# Altium schematic sheet sem4200.SchDoc
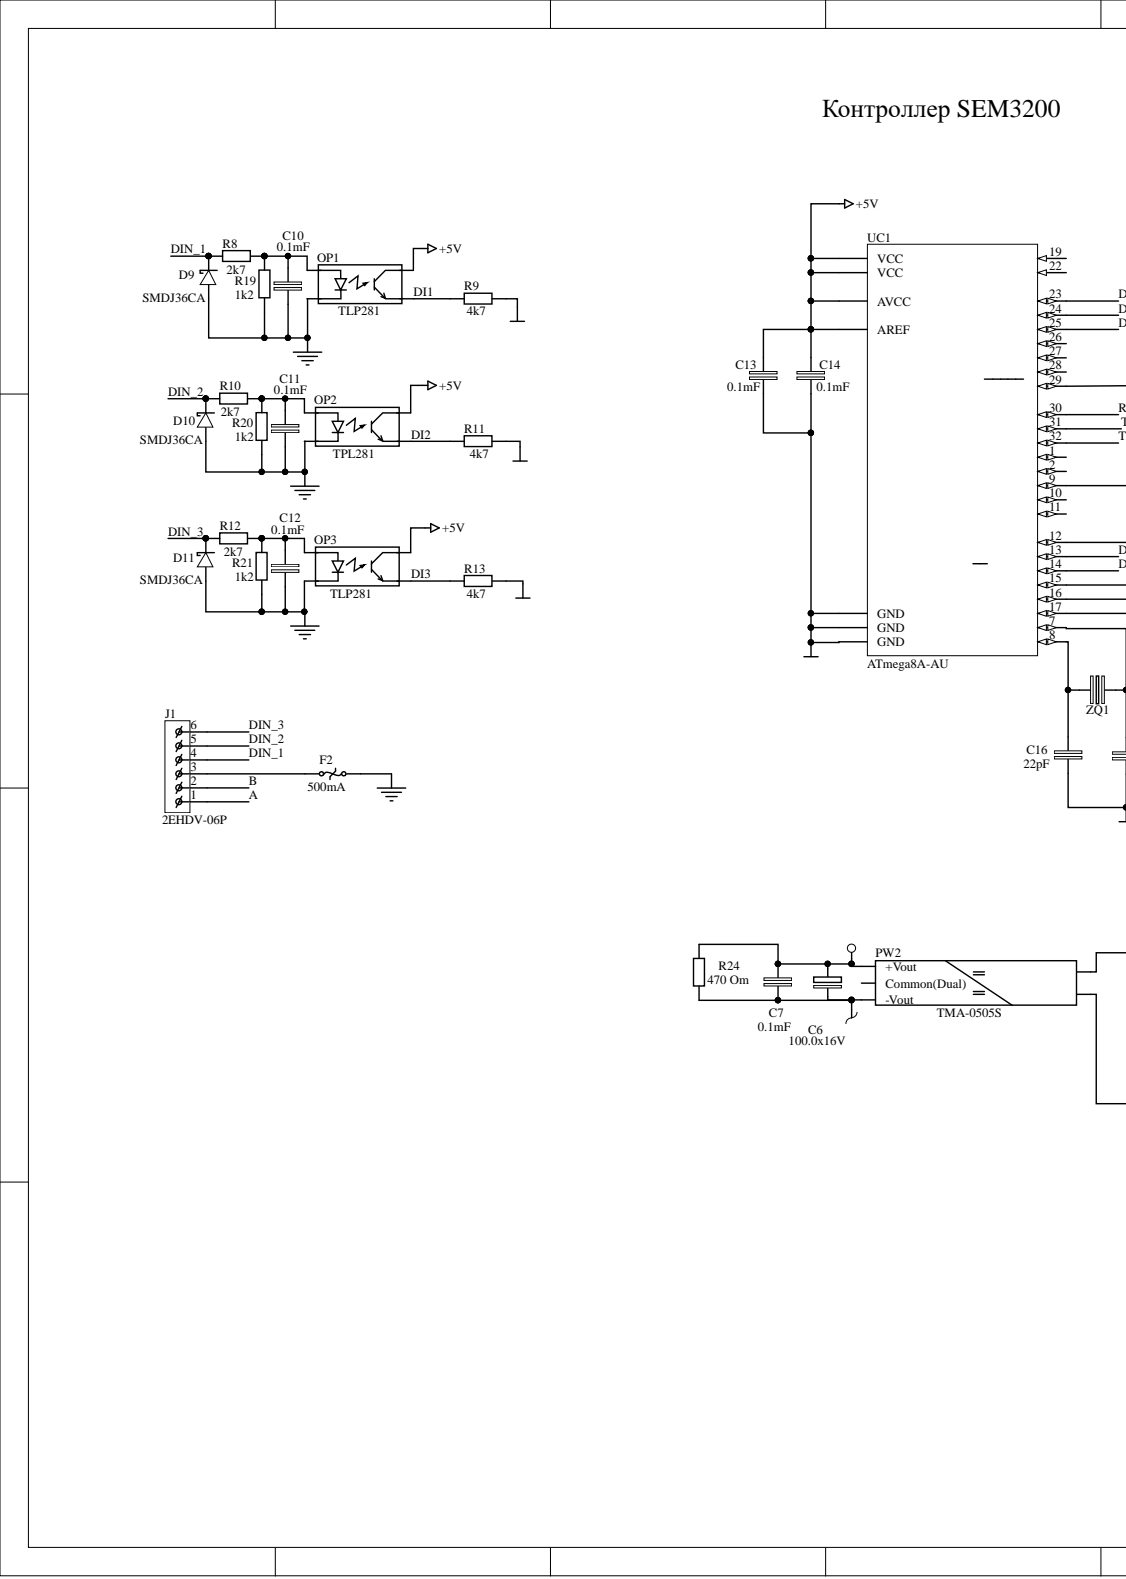
[diagram p0: sem4200.SchDoc, left]
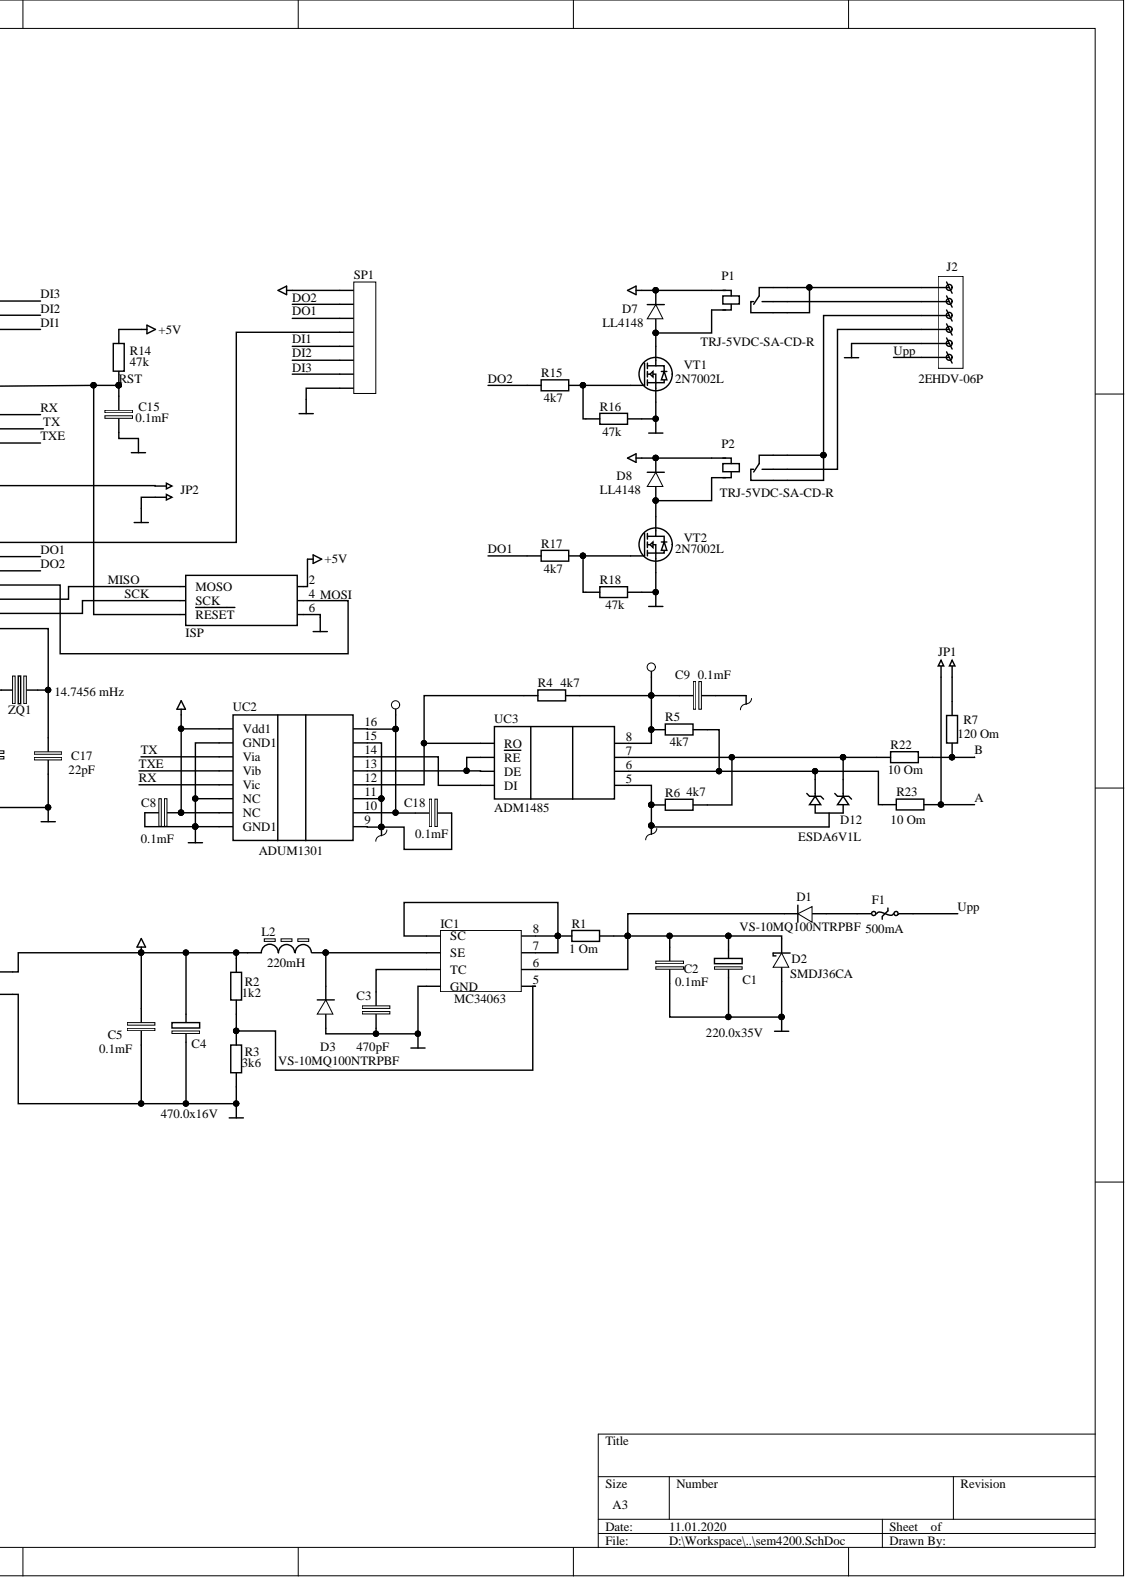
[diagram p1: sem4200.SchDoc, right]

## Parts
C1  220.0x35V  [Cap_2(10x12.5)]
C2  0.1  value 0.1mF  [0805]
C3  470 pF  value 470pF  [0805]
C4  value 470.0x16V  [10x12.5]  (hidden comment: 470.0x35V)
C5  0.1  value 0.1mF  [0805]
C6  value 100.0x16V  [5x10]  (hidden comment: 22.0x16V)
C7  0.1  value 0.1mF  [0805]
C8  0.1  value 0.1mF  [0805]
C9  0.1  value 0.1mF  [0805]
C10  0.1  value 0.1mF  [0805]
C11  0.1  value 0.1mF  [0805]
C12  0.1  value 0.1mF  [0805]
C13  0.1  value 0.1mF  [0805]
C14  0.1  value 0.1mF  [0805]
C15  0.1  value 0.1mF  [0805]
C16  value 22pF  [0805]  (hidden comment: 20p)
C17  value 22pF  [0805]  (hidden comment: 20p)
C18  0.1  value 0.1mF  [0805]
D1  Type: VS-10MQ100NTRPBF  [SMA]  (hidden comment: SS18)
D2  SMDJ36CA  [SMB]
D3  Type: VS-10MQ100NTRPBF  [SMA]  (hidden comment: SS18)
D7  LL4148  [SOD80]
D8  LL4148  [SOD80]
D9  SMDJ36CA  [SMB]
D10  SMDJ36CA  [SMB]
D11  SMDJ36CA  [SMB]
D12  ESDA6V1L  [SOT23]
F1  500mA  [Fuse_termo]
F2  500mA  [Fuse_termo]
IC1  MC34063  [SO-8]
ISP  ISP  [ISP_1]
J1  Type: 2EHDV-06P  [conn6X1]  (hidden comment: Conn_6x1)
J2  Type: 2EHDV-06P  [conn6X1]  (hidden comment: Conn_6x1)
JP1  jamper  [JP_2_2.5]  (value not drawn)
JP2  jamper  [JP_2_2.5]  (value not drawn)
L2  220mH  [Dr_1]
OP1  Type: TLP281  [SOIC127_TPL]  (hidden comment: TLP281GB)
OP2  Type: TPL281  [SOIC127_TPL]  (hidden comment: TLP281GB)
OP3  Type: TLP281  [SOIC127_TPL]  (hidden comment: TLP281GB)
P1  TRJ  [PCB_rele_TRJ]  (value not drawn)
P2  TRJ  Type: TRJ-5VDC-SA-CD-R  [PCB_rele_TRJ]
PW2  TMA_0505  Type: TMA-0505S  [TMA_1W]
R1  1 Om  [1206]
R2  1k2  [0805]
R3  3k6  [0805]
R4  value 4k7  [0805]  (hidden comment: 10k)
R5  4k7  [0805]
R6  4k7  [0805]
R7  120 Om  [1206]
R8  2k7  [2010]
R9  4k7  [0805]
R10  2k7  [2010]
R11  value 4k7  [0805]  (hidden comment: 47k)
R12  2k7  [2010]
R13  value 4k7  [0805]  (hidden comment: 47k)
R14  47k  [0805]
R15  4k7  [0805]
R16  47k  [0805]
R17  4k7  [0805]
R18  47k  [0805]
R19  1k2  [0805]
R20  1k2  [0805]
R21  1k2  [0805]
R22  10 Om  [0805]
R23  10 Om  [0805]
R24  470 Om  [1206]
SP1  SP1  [Conn8x1]
UC1  ATmega8A-AU  [TQFP-32]
UC2  ADUM1301  [SOIC_W]
UC3  ADM1485  [SOIC127P600X175-8N_SOIC_SO8]
VT1  2N7002L  [SOT23]
VT2  2N7002L  [SOT23]
ZQ1  value 14.7456 mHz  [ZQ_1]  (hidden comment: 7.3728)

## Nets
+5V: C4.1 C5.1 C8.1 C13.1 C14.1 D7.2 D8.2 ISP.2 L2.2 OP1.4 OP2.4 OP3.4 P1.1 P2.1 PW2.1 R2.2 R14.2 SP1.8 UC1.4(VCC) UC1.6(VCC) UC1.18(AVCC) UC1.20(AREF) UC2.1(Vdd1) UC2.7(NC)
+5V_I: C6.1 C7.1 C9.2 C18.1 PW2.5(+Vout) R4.1 R5.2 R24.1 UC2.10 UC2.16 UC3.8
E_GND: C10.2 C11.2 C12.2 D9.2 D10.2 D11.2 F2.2 OP1.2 OP2.2 OP3.2 R19.1 R20.1 R21.1
GND: C1.2 C2.2 C3.2 C4.2 C5.2 C8.2 C13.2 C14.2 C15.2 C16.2 C17.2 D2.2 D3.1 IC1.4(GND) ISP.6 J2.2 JP2.2 PW2.2 R3.1 R9.2 R11.2 R13.2 R16.1 R18.1 SP1.1 UC1.3(GND) UC1.5(GND) UC1.21(GND) UC2.2(GND1) UC2.6(NC) UC2.8(GND1) VT1.2 VT2.2
GND_I: C6.2 C7.2 C9.1 C18.2 D12.3 PW2.3(-Vout) R6.2 R24.2 UC2.9 UC2.11 UC2.15 UC3.5
A: J1.1 JP1.1 R23.1
B: J1.2 R7.1 R22.1
DI1: OP1.3 R9.1 SP1.4 UC1.25
DI2: OP2.3 R11.1 SP1.3 UC1.24
DI3: OP3.3 R13.1 SP1.2 UC1.23
DIN_1: D9.1 J1.4 R8.1
DIN_2: D10.1 J1.5 R10.1
DIN_3: D11.1 J1.6 R12.1
DO1: R17.2 SP1.6 UC1.13
DO2: R15.2 SP1.7 UC1.14
MISO: ISP.1(MOSO) UC1.16
RST: C15.1 ISP.5(RESET) R14.1 UC1.29
RX: UC1.30 UC2.5(Vic)
SCK: ISP.3(SCK) UC1.17
TX: UC1.31 UC2.3(Via)
TXE: UC1.32 UC2.4(Vib)
Upp: F1.2 J2.1
NetC1_1: C1.1 C2.1 D1.2 D2.1 IC1.6 R1.2
NetC3_1: C3.1 IC1.3(TC)
NetC10_1: C10.1 OP1.1 R8.2 R19.2
NetC11_1: C11.1 OP2.1 R10.2 R20.2
NetC12_1: C12.1 OP3.1 R12.2 R21.2
NetC16_1: C16.1 UC1.8 ZQ1.1
NetC17_1: C17.1 UC1.7 ZQ1.2
NetD1_1: D1.1 F1.1
NetD3_2: D3.2 IC1.2(SE) L2.1
NetD7_1: D7.1 P1.5 VT1.3
NetD8_1: D8.1 P2.5 VT2.3
NetD12_1: D12.1 R6.1 R22.2 UC3.7
NetD12_2: D12.2 R5.1 R23.2 UC3.6
NetF2_1: F2.1 J1.3
NetIC1_1: IC1.1(SC) IC1.7 IC1.8 R1.1
NetIC1_5: IC1.5 R2.1 R3.2
NetISP_4: ISP.4 UC1.15
NetJ2_3: J2.3 P2.3
NetJ2_4: J2.4 P2.2 P2.4
NetJ2_5: J2.5 P1.3
NetJ2_6: J2.6 P1.2 P1.4
NetJP1_2: JP1.2 R7.2
NetJP2_1: JP2.1 UC1.9
NetR4_2: R4.2 UC2.12 UC3.1(RO)
NetR15_1: R15.1 R16.2 VT1.1
NetR17_1: R17.1 R18.2 VT2.1
NetSP1_5: SP1.5 UC1.12
NetUC2_13: UC2.13 UC3.2(RE) UC3.3(DE)
NetUC2_14: UC2.14 UC3.4(DI)
MOSI:

Not connected: PW2 4(Common(Dual)); UC1 1 2 10 11 19 22 26 27 28

## Library data of the parts
C1 = Cap_pol2: Type: 10x12.5; Component Kind: Standard; Library Name: Lib_my1.SchLib; Value: 220.0x35V
C2 C3 C5 C7-C18 = cap_1: Component Kind: Standard; Library Name: Lib_my1.SchLib
C4 = Cap_pol2: Value: 470.0x16V; Component Kind: Standard; Library Name: Lib_my1.SchLib
C6 = Cap_pol2: Component Kind: Standard; Library Name: Lib_my1.SchLib
D1 D3 = Diod_30BQ100: Component Kind: Standard; Library Name: Lib_my1.SchLib
D2 D9-D11 = Diodes_SMB: Component Kind: Standard; Library Name: Lib_my1.SchLib; Type: SMDJ36CA
D7 D8 = Diodes_4148: Component Kind: Standard; Library Name: Lib_my1.SchLib; Type: LL4148
D12 = Diod_ESDA: Component Kind: Standard; Library Name: Lib_my1.SchLib; Type: ESDA6V1L
F1 F2 = Fuse_term: Type: RXE050; Component Kind: Standard; Library Name: Lib_my1.SchLib; Value: 500mA
IC1 = MC34063_1: Component Kind: Standard; Library Name: Lib_my1.SchLib; Type: MC34063
ISP = ISP_1: Component Kind: Standard; Library Name: Lib_my1.SchLib; Type: PLD-6 (DS1021-2x3S)
JP1 JP2 = JP_2x2.5: Component Kind: Standard; Library Name: Lib_my1.SchLib; Type: PLS-2 (DS1021-1x2)
L2 = Dr_1: Type: RLB0712-221KL; Component Kind: Standard; Library Name: Lib_my1.SchLib; Value: 220mH
OP1-OP3 = opto_TLP: Component Kind: Standard; Library Name: Lib_my1.SchLib
P1 P2 = Rele_TRJ: Component Kind: Standard; Library Name: Lib_my1.SchLib
  P1: Type: TRJ-5VDC-SA-CD-R
PW2 = TRACO_PW1: Component Kind: Standard; Library Name: Lib_my1.SchLib
R1-R24 = rez_1: Component Kind: Standard; Library Name: Lib_my1.SchLib
  R1: Value: 1 Om
  R2 R19-R21: Value: 1k2
  R3: Value: 3k6
  R5 R6 R9 R15 R17: Value: 4k7
  R7: Value: 120 Om
  R8 R10 R12: Value: 2k7
  R14 R16 R18: Value: 47k
  R22 R23: Value: 10 Om
  R24: Value: 470 Om
SP1 = Conn8x1: Component Kind: Standard; Library Name: Lib_my1.SchLib; Type: PLS-8 (DS1021-1x8)
UC1 = ATmega8A-AU: 8-bit AVR Microcontroller, 8KB Flash, 512 Bytes EEPROM, 1KB SRAM, 32-pin TQFP, Industrial Grade (-40°C to 85°C); Code_JEDEC: MS-026-ABA; Component Kind: Standard; ComponentLink1Description: Manufacturer Link; ComponentLink1URL: http://www.atmel.com/products/avr/default.asp?; ComponentLink2Description: ATmega8A Datasheet; ComponentLink2URL: http://www.atmel.com/dyn/resources/prod_documents/doc8159.pdf; ComponentLink3Description: ATmega8A Summary; ComponentLink3URL: http://www.atmel.com/dyn/resources/prod_documents/8159S.pdf; ComponentLink4Description: Atmel.com Home; ComponentLink4URL: http://www.atmel.com; DatasheetVersion: revC, Jul-2009; Library Name: Atmel Microcontroller 8-Bit megaAVR.IntLib; PackageDescription: 32-Lead, 7x7x1.0 mm Body, 0.8mm Lead Pitch, Thin Profile Plastic Quad Flat Package (TQFP); PackageReference: 32A; PackageVersion: revB, Oct-2001; Published: 1-Apr-2010; Supplier 1: Digi-Key; Supplier 2: Farnell; Supplier 3: Mouser; Supplier Part Number 1: ATMEGA8A-AU-ND; Supplier Part Number 2: 1748532; Supplier Part Number 3: 556-ATMEGA8A-AU; Publisher: Altium Limited
UC3 = ADM485: Component Kind: Standard; Library Name: Lib_my1.SchLib
VT1 VT2 = n_chanel_tranzistor1: Component Kind: Standard; Library Name: Lib_my1.SchLib; Type: 2N7002L
ZQ1 = ZQ_1: Component Kind: Standard; Library Name: Lib_my1.SchLib; Type: HC-49S
J1 J2 = Conn_6x1: Component Kind: Standard; Library Name: Lib_my1.SchLib
UC2 = ADUM1301: Component Kind: Standard; Library Name: Lib_my1.SchLib; Type: ADUM1301
